# Altium schematic sheet Input Digital.SchDoc("Input Digital")
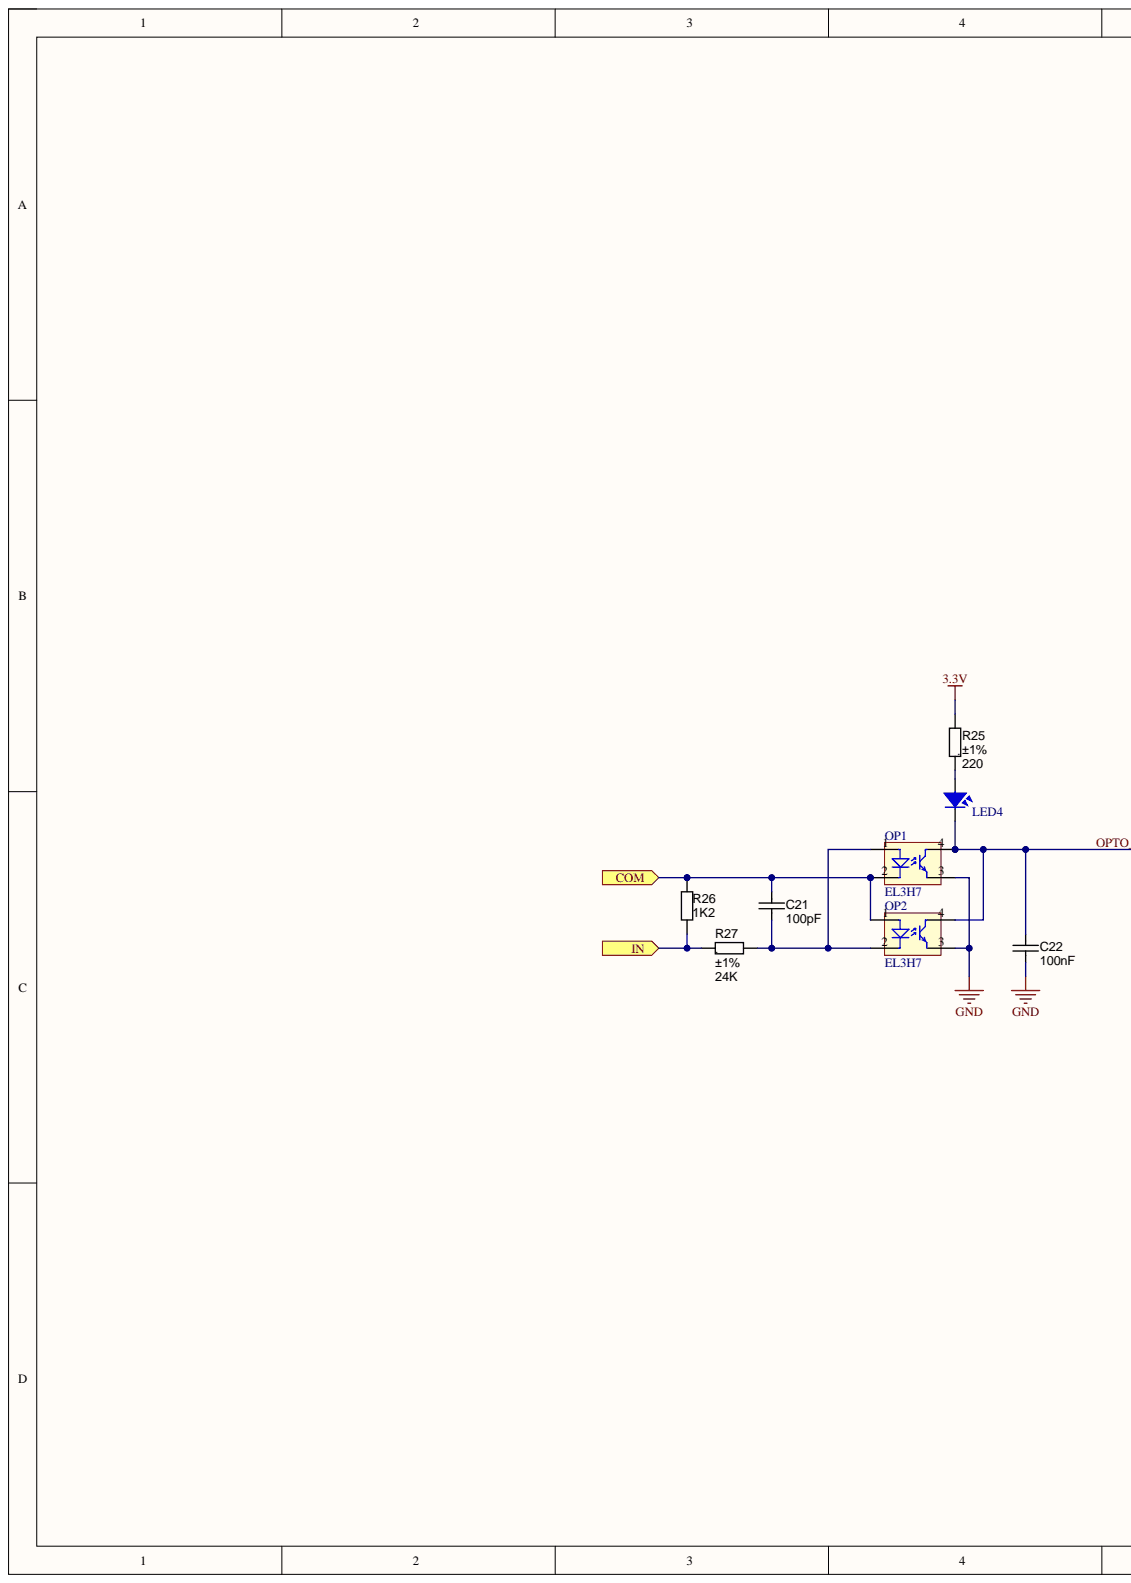
[diagram p0: Input Digital.SchDoc, left]
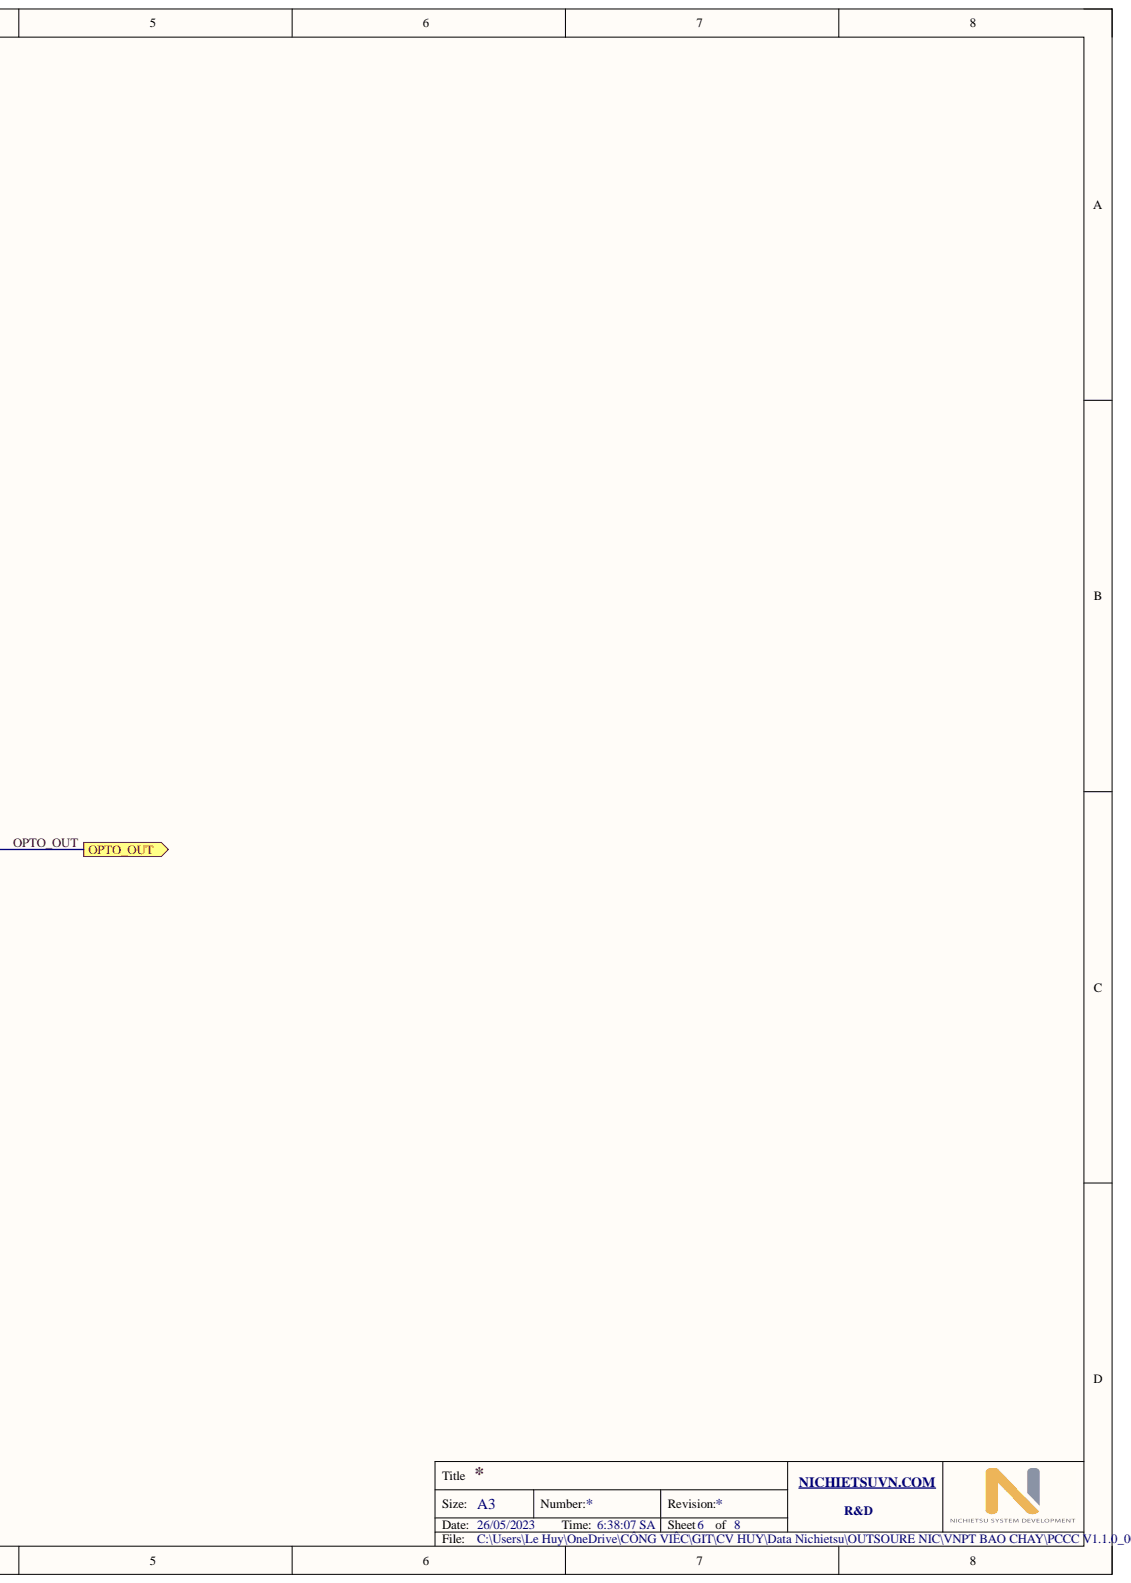
[diagram p1: Input Digital.SchDoc, right]

## Parts
C21  100pF  [CAPC1608X90N]
C22  100nF  [CAPC1608X90N]
LED4  LED  [LED-0805-Green]
OP1  EL3H7  [IC_SMD_SSOP4_NEW]
OP2  EL3H7  [IC_SMD_SSOP4_NEW]
R25  value 220  Tolerance: ±1%  [RESC1608X55N]  (hidden comment: 10K_0603_1%)
R26  value 1K2  [RES_2W_AXIAL_11x4_STAND]  (hidden comment: Metal Film)
R27  value 24K  Tolerance: ±1%  [RESC2012X70N]  (hidden comment: 24K_0805_1%)

## Ports
COM, IN, OPTO_OUT

## Nets
3.3V: R25.2
GND: C22.2 OP1.3 OP2.3
COM: C21.1 OP1.2 OP2.1 R26.1 ; port COM
IN: R26.2 R27.1 ; port IN
OPTO_OUT: C22.1 LED4.2 OP1.4 OP2.4 ; port OPTO_OUT
NetC21_2: C21.2 OP1.1 OP2.2 R27.2
NetLED4_1: LED4.1 R25.1

## Library data of the parts
C21 = CAPC_100pF_0603_C0G_NP0_100V_5%: 100pF ±5% 100V Ceramic Capacitor C0G, NP0 0603 (1608 Metric); Manufacturer 1: TDK Corporation; Pcs 2: 10; Applications: Automotive; Component Kind: Standard; Operating Temperature: -55°C ~ 125°C; Package / Case: 0603 (1608 Metric); Packaging: Digi-Reel®; Price 2: 1800; Ratings: AEC-Q200; Supplier 1: Digi-Key; Supplier 2: https://www.thegioiic.com; Supplier Part Number 1: 445-5724-6-ND; Supplier Part Number 2: https://www.thegioiic.com/products/tu-gom-0603-100pf-50v; Temperature Coefficient: C0G, NP0; Tolerance: ±5%; Value: 100pF; Voltage - Rated: 100V; Library Name: SangMV.SchLib
C22 = CAPC_100nF_0603_X7R_50V_10%: 0.1µF ±10% 50V Ceramic Capacitor X7R 0603 (1608 Metric); Manufacturer 1: TDK Corporation; Manufacturer Part Number 1: CGA3E2X7R1H104K080AA; Pcs 2: 10; Applications: Automotive; Component Kind: Standard; Library Name: All Library.SchLib; Operating Temperature: -55°C ~ 125°C; Package / Case: 0603 (1608 Metric); Packaging: Digi-Reel®; Price 2: 1800; Ratings: AEC-Q200; Supplier 1: Digi-Key; Supplier 2: www.thegioiic.com; Supplier Part Number 1: 445-5666-6-ND; Supplier Part Number 2: https://www.thegioiic.com/products/tu-gom-0603-100nf-0-1uf-50v; Temperature Coefficient: X7R; Tolerance: ±10%; Value: 100nF; Voltage - Rated: 50V
LED4 = LED SMD: Value: LED 3 - 5 ly 2 Colour; Component Kind: Standard; Library Name: thu vien 3d.IntLib
OP1 OP2 = OPTO_EL3H7: Photo Transistor; Manufacturer 1: Everlight Electronics Co Ltd; Manufacturer Part Number 1: EL3H7(TA)-VG; Pcs 2: 1; Component Kind: Standard; Library Name: All Library.SchLib; Operating Temperature: -55°C ~ 110°C; Price 2: 1100; Supplier 1: Digi-Key; Supplier 2: linhkien.cxt.vn; Supplier Part Number 1: 1080-1198-6-ND; Supplier Part Number 2: https://linhkien.cxt.vn/2637-el3h7bta-vg.html
R25 = RES_10K_0603_1%: 10 kOhms ±1% 0.1W, 1/10W Chip Resistor 0603 (1608 Metric) Anti-Sulfur, Automotive AEC-Q200, Moisture Resistant Thin Film; Manufacturer: Stackpole Electronics Inc; Manufacturer Part Number: RMCF0603FT10K0; Pcs 2: 50; Temperature Coefficient: ±100ppm/°C; Component Kind: Standard; Library Name: All Library.SchLib; Operating Temperature: -55°C ~ 155°C; Package / Case: 0603 (1608 Metric); Power (Watts): 1/10W; Price 2: 2000; Ratings: Automotive AEC-Q200; Supplier 1: Digi-Key; Supplier 2: www.thegioiic.com; Supplier Part Number 1: RMCF0603FT10K0DKR-ND; Supplier Part Number 2: https://www.thegioiic.com/products/dien-tro-10-kohm-0603-1
R26 = RES_390R_Axial_2W_5%: Resistor THD 390 Ohm 2W 5% Metal Film; Manufacturer 1: Yageo; Manufacturer Part Number 1: FMP200JR-52-390R; Pcs 2: 1; Component Kind: Standard; Library Name: All Library.SchLib; Power (Watts): 2W; Price 2: 500; Size / Dimension: 0.154" Dia x 0.354" L (3.90mm x 9.00mm); Supplier 1: Digi-Key; Supplier 2: https://www.thegioiic.com; Supplier Part Number 1: 390ZTR-ND; Supplier Part Number 2: https://www.thegioiic.com/products/dien-tro-390-ohm-2w-5-4-vong-mau; Tolerance: ±5%
R27 = RES_24K_0805_1%: 24 kOhms ±1% 0.125W, 1/8W Chip Resistor 0805 (2012 Metric) Automotive AEC-Q200 Thick Film; Manufacturer: Stackpole Electronics Inc; Manufacturer Part Number: RMCF0805FT10K5; Pcs 2: 50; Power (Watts): 0.1W, 1/10W; Temperature Coefficient: ±100ppm/°C; Component Kind: Standard; Features: Automotive AEC-Q200; Library Name: All Library.SchLib; Operating Temperature: -55°C ~ 155°C; Package / Case: 0805 (2012 Metric); Price 2: 1800; Supplier 1: Digi-Key; Supplier 2: www.thegioiic.com; Supplier Part Number 1: RMCF0805FT24K0DKR-ND; Supplier Part Number 2: https://www.thegioiic.com/products/dien-tro-24-kohm-0805-5
